# Altium schematic sheet ADC.SchDoc(ADC)
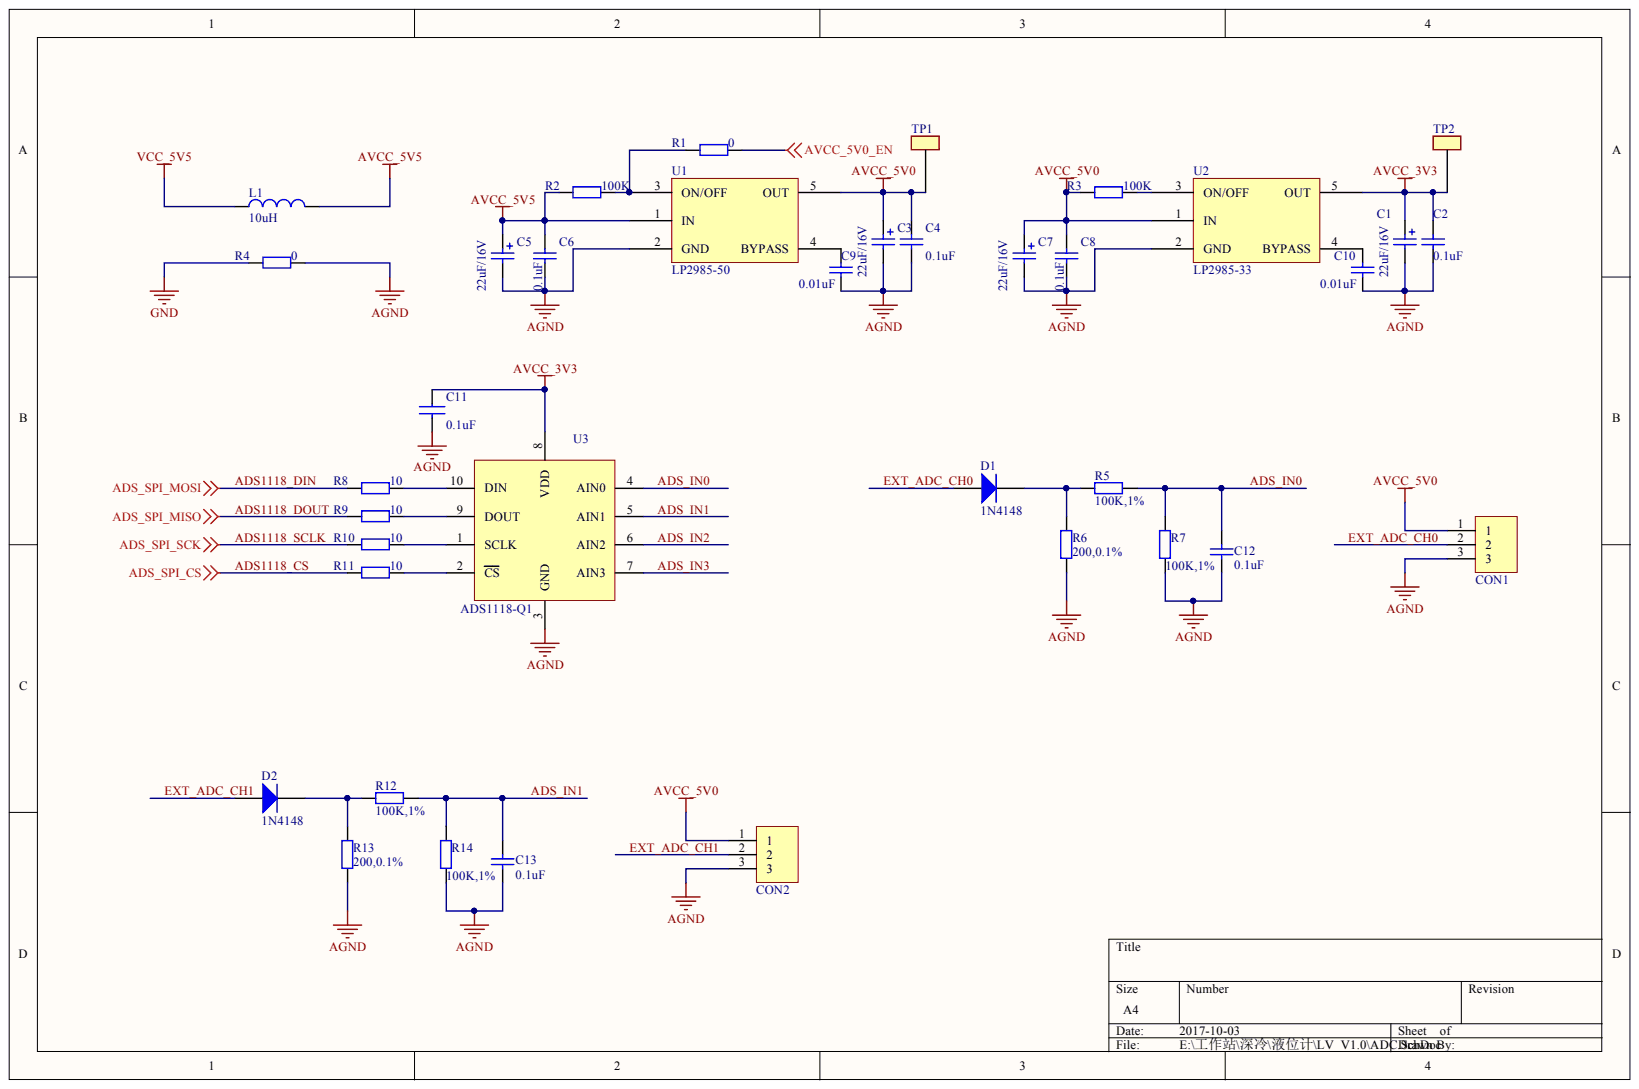
[diagram p0: ADC.SchDoc]

## Parts
C1  value 22uF/16V  [EIA1206]
C2  value 0.1uF  [0603C]  (hidden comment: Capacitor)
C3  value 22uF/16V  [EIA1206]
C4  value 0.1uF  [0603C]  (hidden comment: Capacitor)
C5  value 22uF/16V  [EIA1206]
C6  value 0.1uF  [0603C]  (hidden comment: Capacitor)
C7  value 22uF/16V  [EIA1206]
C8  value 0.1uF  [0603C]  (hidden comment: Capacitor)
C9  value 0.01uF  [0603C]  (hidden comment: Capacitor)
C10  value 0.01uF  [0603C]  (hidden comment: Capacitor)
C11  value 0.1uF  [0603C]  (hidden comment: CAP NP)
C12  C?  value 0.1uF  [L0603]
C13  C?  value 0.1uF  [L0603]
CON1  CONNECTOR_3PIN  [FPC1.0-3P]  (value not drawn)
CON2  CONNECTOR_3PIN  [FPC1.0-3P]  (value not drawn)
D1  D?  Model: 1N4148  [1206]
D2  D?  Model: 1N4148  [1206]
L1  value 10uH  [0805R]  (hidden comment: Inductor)
R1  value 0  [L0603]  (hidden comment: Resistor)
R2  value 100K  [L0603]  (hidden comment: Resistor)
R3  value 100K  [L0603]  (hidden comment: Resistor)
R4  value 0  [1206]  (hidden comment: Resistor)
R5  value 100K,1%  [L0603]  (hidden comment: Resistor)
R6  value 200,0.1%  [1206]  (hidden comment: Resistor)
R7  value 100K,1%  [L0603]  (hidden comment: Resistor)
R8  value 10  [L0603]  (hidden comment: Resistor)
R9  value 10  [L0603]  (hidden comment: Resistor)
R10  value 10  [L0603]  (hidden comment: Resistor)
R11  value 10  [L0603]  (hidden comment: Resistor)
R12  value 100K,1%  [L0603]  (hidden comment: Resistor)
R13  value 200,0.1%  [1206]  (hidden comment: Resistor)
R14  value 100K,1%  [L0603]  (hidden comment: Resistor)
TP1  TEST POINT_0  [TP]  (value not drawn)
TP2  TEST POINT_0  [TP]  (value not drawn)
U1  LP2985  value LP2985-50  [SOT23-5]
U2  LP2985  value LP2985-33  [SOT23-5]
U3  ADS1118-Q1  [VSSOP10]

## Nets
AGND: C1.2 C2.2 C3.2 C4.2 C5.2 C6.2 C7.2 C8.2 C9.2 C10.2 C11.2 C12.1 C13.1 CON1.3 CON2.3 R4.1 R6.1 R7.1 R13.1 R14.1 U1.2(GND) U2.2(GND) U3.3(GND)
AVCC_3V3: C1.1 C2.1 C11.1 TP2.1 U2.5(OUT) U3.8(VDD)
AVCC_5V0: C3.1 C4.1 C7.1 C8.1 CON1.1 CON2.1 R3.2 TP1.1 U1.5(OUT) U2.1(IN)
AVCC_5V0_EN: R1.1
AVCC_5V5: C5.1 C6.1 L1.2 R2.2 U1.1(IN)
GND: R4.2
VCC_5V5: L1.1
ADS1118_CS: R11.2
ADS1118_DIN: R8.2
ADS1118_DOUT: R9.2
ADS1118_SCLK: R10.2
ADS_IN0: C12.2 R5.2 R7.2 U3.4(AIN0)
ADS_IN1: C13.2 R12.2 R14.2 U3.5(AIN1)
ADS_IN2: U3.6(AIN2)
ADS_IN3: U3.7(AIN3)
EXT_ADC_CH0: CON1.2 D1.1
EXT_ADC_CH1: CON2.2 D2.1
NetC9_1: C9.1 U1.4(BYPASS)
NetC10_1: C10.1 U2.4(BYPASS)
NetD1_2: D1.2 R5.1 R6.2
NetD2_2: D2.2 R12.1 R13.2
NetR1_2: R1.2 R2.1 U1.3(ON/OFF)
NetR3_1: R3.1 U2.3(ON/OFF)
NetR8_1: R8.1 U3.10(DIN)
NetR9_1: R9.1 U3.9(DOUT)
NetR10_1: R10.1 U3.1(SCLK)
NetR11_1: R11.1 U3.2(CS)

## Library data of the parts
C1 C3 C5 C7 = Cap pol: Component Kind: Standard; Library Name: LNG.SCHLIB
C2 C4 C6 C8-C10 C12 C13 = Cap: Component Kind: Standard
  C2 C4 C6 C8-C10: Description: Capacitor; LatestRevisionDate: 17-Jul-2002; LatestRevisionNote: Re-released for DXP Platform.; Library Name: Miscellaneous Devices.IntLib; PackageDescription: Radial Cap, Thru-Hole; 2 Leads; 0.3 in Pin Spacing; PackageReference: RAD-0.3; Published: 8-Jun-2000; Signal Integrity: Cap; Simulation: CAP; Publisher: Altium Limited
  C12 C13: Library Name: LNG.SCHLIB
CON1 CON2 = CONNECTOR_3PIN: Value: XH2.54-3; Component Kind: Standard; Library Name: MyLib.SCHLIB
D1 D2 = Diode: Component Kind: Standard; Library Name: MyLib.SCHLIB
R1-R14 = Res: Component Kind: Standard; Library Name: Lib1.SCHLIB
C11 = CAP NP: Component Kind: Standard; Library Name: MAINBOARD_Library.SchLib
L1 = Inductor: Component Kind: Standard; Library Name: LNG.SCHLIB
TP1 TP2 = TEST POINT_0: Component Kind: Standard; Library Name: MAINBOARD_Library.SchLib
U1 U2 = LP2985: Component Kind: Standard; Library Name: MyLib.SCHLIB
U3 = ADS1118-Q1: Component Kind: Standard; Value: ADS1118-Q1; Library Name: Bio.SchLib
